# Altium schematic sheet Sheet1.SchDoc
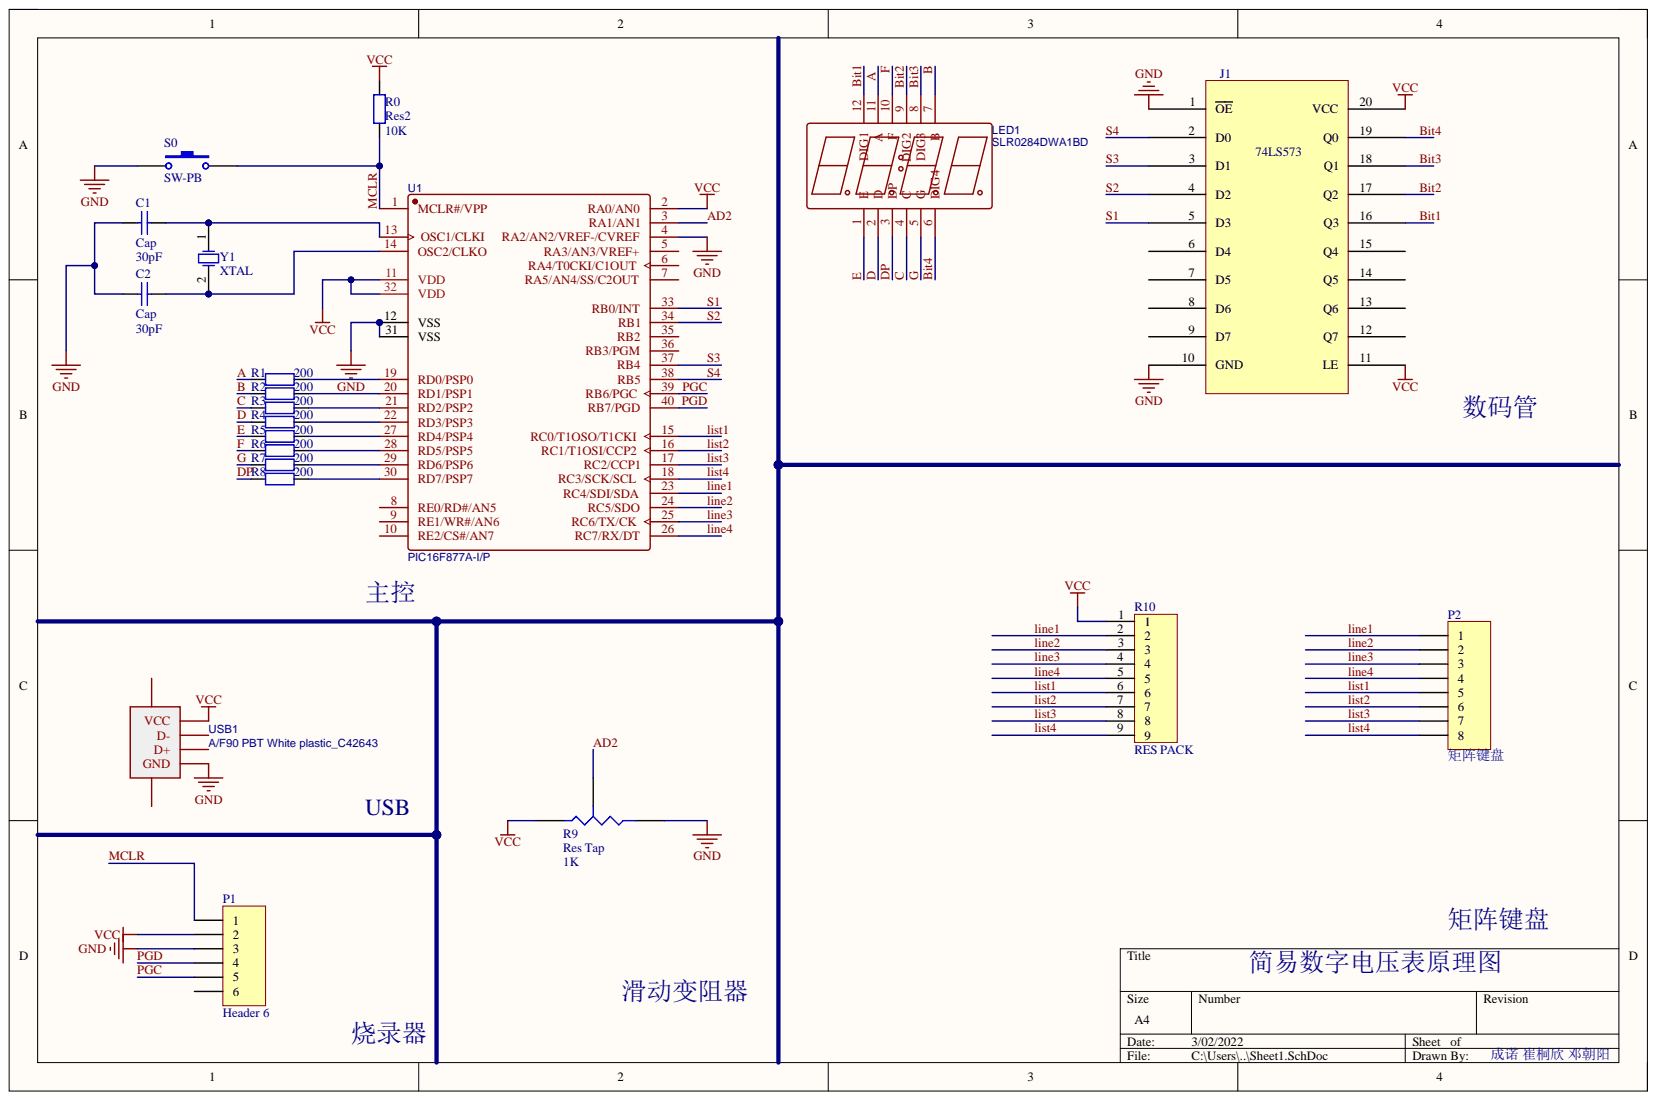
[diagram p0: Sheet1.SchDoc]

## Parts
C1  Cap  value 30pF  [RAD-0.1]
C2  Cap  value 30pF  [RAD-0.1]
J1  74LS573  [74LS573]
LED1  SLR0284DWA1BD  [LED]
P1  Header 6  [HDR1X6]
P2  矩阵键盘  [HDR1X8]
R0  Res2  value 10K  [AXIAL-0.4]
R1  value 200  [AXIAL-0.4]  (hidden comment: Res2)
R2  value 200  [AXIAL-0.4]  (hidden comment: Res2)
R3  value 200  [AXIAL-0.4]  (hidden comment: Res2)
R4  value 200  [AXIAL-0.4]  (hidden comment: Res2)
R5  value 200  [AXIAL-0.4]  (hidden comment: Res2)
R6  value 200  [AXIAL-0.4]  (hidden comment: Res2)
R7  value 200  [AXIAL-0.4]  (hidden comment: Res2)
R8  value 200  [AXIAL-0.4]  (hidden comment: Res2)
R9  Res Tap  value 1K  [HDR1X3]
R10  RES PACK  [HDR1X9]
S0  SW-PB  [Switch]
U1  PIC16F877A-I/P  [PIC16F877A]
USB1  A/F90 PBT White plastic_C42643  [USB]
Y1  XTAL  [XTAL]

## Nets
GND: C1.1 C2.1 J1.1(OE) J1.10(GND) P1.3 R9.3 S0.1 U1.4(RA2/AN2/VREF-/CVREF) U1.12(VSS) U1.31(VSS) USB1.4(GND)
VCC: J1.11(LE) J1.20(VCC) P1.2 R0.2 R9.1 R10.1 U1.2(RA0/AN0) U1.11(VDD) U1.32(VDD) USB1.1(VCC)
A: LED1.11(A) R1.1
AD2: R9.2 U1.3(RA1/AN1)
B: LED1.7(B) R2.1
Bit1: J1.16(Q3) LED1.12(DIG1)
Bit2: J1.17(Q2) LED1.9(DIG2)
Bit3: J1.18(Q1) LED1.8(DIG3)
Bit4: J1.19(Q0) LED1.6(DIG4)
C: LED1.4(C) R3.1
D: LED1.2(D) R4.1
DP: LED1.3(DP) R8.1
E: LED1.1(E) R5.1
F: LED1.10(F) R6.1
G: LED1.5(G) R7.1
line1: P2.1 R10.2 U1.23(RC4/SDI/SDA)
line2: P2.2 R10.3 U1.24(RC5/SDO)
line3: P2.3 R10.4 U1.25(RC6/TX/CK)
line4: P2.4 R10.5 U1.26(RC7/RX/DT)
list1: P2.5 R10.6 U1.15(RC0/T1OSO/T1CKI)
list2: P2.6 R10.7 U1.16(RC1/T1OSI/CCP2)
list3: P2.7 R10.8 U1.17(RC2/CCP1)
list4: P2.8 R10.9 U1.18(RC3/SCK/SCL)
MCLR: P1.1 R0.1 S0.2 U1.1(MCLR#/VPP)
PGC: P1.5 U1.39(RB6/PGC)
PGD: P1.4 U1.40(RB7/PGD)
S1: J1.5(D3) U1.33(RB0/INT)
S2: J1.4(D2) U1.34(RB1)
S3: J1.3(D1) U1.37(RB4)
S4: J1.2(D0) U1.38(RB5)
NetC1_2: C1.2 U1.13(OSC1/CLKI) Y1.1
NetC2_2: C2.2 U1.14(OSC2/CLKO) Y1.2
NetR1_2: R1.2 U1.19(RD0/PSP0)
NetR2_2: R2.2 U1.20(RD1/PSP1)
NetR3_2: R3.2 U1.21(RD2/PSP2)
NetR4_2: R4.2 U1.22(RD3/PSP3)
NetR5_2: R5.2 U1.27(RD4/PSP4)
NetR6_2: R6.2 U1.28(RD5/PSP5)
NetR7_2: R7.2 U1.29(RD6/PSP6)
NetR8_2: R8.2 U1.30(RD7/PSP7)

Not connected: J1 6(D4) 7(D5) 8(D6) 9(D7) 12(Q7) 13(Q6) 14(Q5) 15(Q4); P1 6; U1 5(RA3/AN3/VREF+) 6(RA4/T0CKI/C1OUT) 7(RA5/AN4/SS/C2OUT) 8(RE0/RD#/AN5) 9(RE1/WR#/AN6) 10(RE2/CS#/AN7) 35(RB2) 36(RB3/PGM); USB1 0 2(D-) 3(D+)

## Library data of the parts
C1 = Cap: Capacitor; Value: 30pF; Component Kind: Standard; LatestRevisionDate: 17-Jul-2002; LatestRevisionNote: Re-released for DXP Platform.; Library Name: Miscellaneous Devices.IntLib; PackageDescription: Radial Cap, Thru-Hole; 2 Leads; 0.3 in Pin Spacing; PackageReference: RAD-0.3; Published: 8-Jun-2000; Signal Integrity: Cap; Simulation: CAP; Publisher: Altium Limited
C2 = Cap: Capacitor; Component Kind: Standard; LatestRevisionDate: 17-Jul-2002; LatestRevisionNote: Re-released for DXP Platform.; Library Name: Miscellaneous Devices.IntLib; PackageDescription: Radial Cap, Thru-Hole; 2 Leads; 0.3 in Pin Spacing; PackageReference: RAD-0.3; Published: 8-Jun-2000; Signal Integrity: Cap; Simulation: CAP; Publisher: Altium Limited
LED1 = SLR0284DWA1BD: BOM_Manufacturer: SUNLIGHT; Contributor: LCSC; BOM_JLCPCB Part Class: Extended Part; BOM_Manufacturer Part: SLR0284DWA1BD; BOM_Supplier: LCSC; BOM_Supplier Part: C225888; Component Kind: Standard; Library Name: Free Documents.IntLib; spicePre: L; spiceSymbolName: SLR0284DWA1BD
P1 = Header 6: Header, 6-Pin; Component Kind: Standard; LatestRevisionDate: 17-Jul-2002; LatestRevisionNote: Re-released for DXP Platform.; Library Name: Miscellaneous Connectors.IntLib; Signal Integrity: Connector; Publisher: Altium Limited
P2 = Header 8: Header, 8-Pin; Component Kind: Standard; LatestRevisionDate: 17-Jul-2002; LatestRevisionNote: Re-released for DXP Platform.; Library Name: Miscellaneous Connectors.IntLib; Signal Integrity: Connector; Publisher: Altium Limited
R0-R8 = Res2: Resistor; Component Kind: Standard; LatestRevisionDate: 17-Jul-2002; LatestRevisionNote: Re-released for DXP Platform.; Library Name: Miscellaneous Devices.IntLib; PackageDescription: Axial Device, Thru-Hole; 2 Leads; 0.4 in Pin Spacing; PackageReference: AXIAL-0.4; Published: 8-Jun-2000; Signal Integrity: Res; Simulation: RESISTOR; Publisher: Altium Limited
R9 = Res Tap: Tapped Resistor; Set Position: 0.5; Sim Note: Enter the Resistance value and the set point into parameter fields.; Component Kind: Standard; LatestRevisionDate: 17-Jul-2002; LatestRevisionNote: Re-released for DXP Platform.; Library Name: Miscellaneous Devices.IntLib; PackageDescription: Variable Resistor, Thru-Hole; 3 Leads; PackageReference: VR3; Published: 24-Mar-1999; Signal Integrity: Res Tap; Simulation: POT; Publisher: Altium Limited
S0 = SW-PB: Switch; Component Kind: Standard; LatestRevisionDate: 17-Jul-2002; LatestRevisionNote: Re-released for DXP Platform.; Library Name: Miscellaneous Devices.IntLib; PackageDescription: Switch; 2 Leads; PackageReference: SPST-2; Published: 24-Mar-1999; Publisher: Altium Limited
U1 = PIC16F877A-I/P: BOM_Manufacturer: MICROCHIP; BOM_JLCPCB Part Class: Extended Part; BOM_Manufacturer Part: PIC16F877A-I/P; BOM_Supplier: LCSC; BOM_Supplier Part: C8766; Component Kind: Standard; Library Name: Free Documents.IntLib; spicePre: X; spiceSymbolName: PIC16F877A-I/P
USB1 = A/F90 PBT White plastic_C42643: BOM_Manufacturer: ValuePro; BOM_JLCPCB Part Class: Extended Part; BOM_Manufacturer Part: A/F90 PBT White plastic; BOM_Supplier: LCSC; BOM_Supplier Part: C42643; Component Kind: Standard; Library Name: Free Documents.IntLib; spicePre: U; spiceSymbolName: A/F90 PBT White plastic_C42643
Y1 = XTAL: Crystal Oscillator; Component Kind: Standard; LatestRevisionDate: 17-Jul-2002; LatestRevisionNote: Re-released for DXP Platform.; Library Name: Miscellaneous Devices.IntLib; PackageDescription: Crystal, Thru-Hole; 2 Leads; Body 3.1 x 8.2 mm (Dia.xH); PackageReference: R38; Published: 8-Jun-2000; Simulation: XTAL; Publisher: Altium Limited
J1 = 74LS573: Component Kind: Standard
R10 = RES PACK: Component Kind: Standard
